# Altium schematic sheet Front_Panel.SchDoc
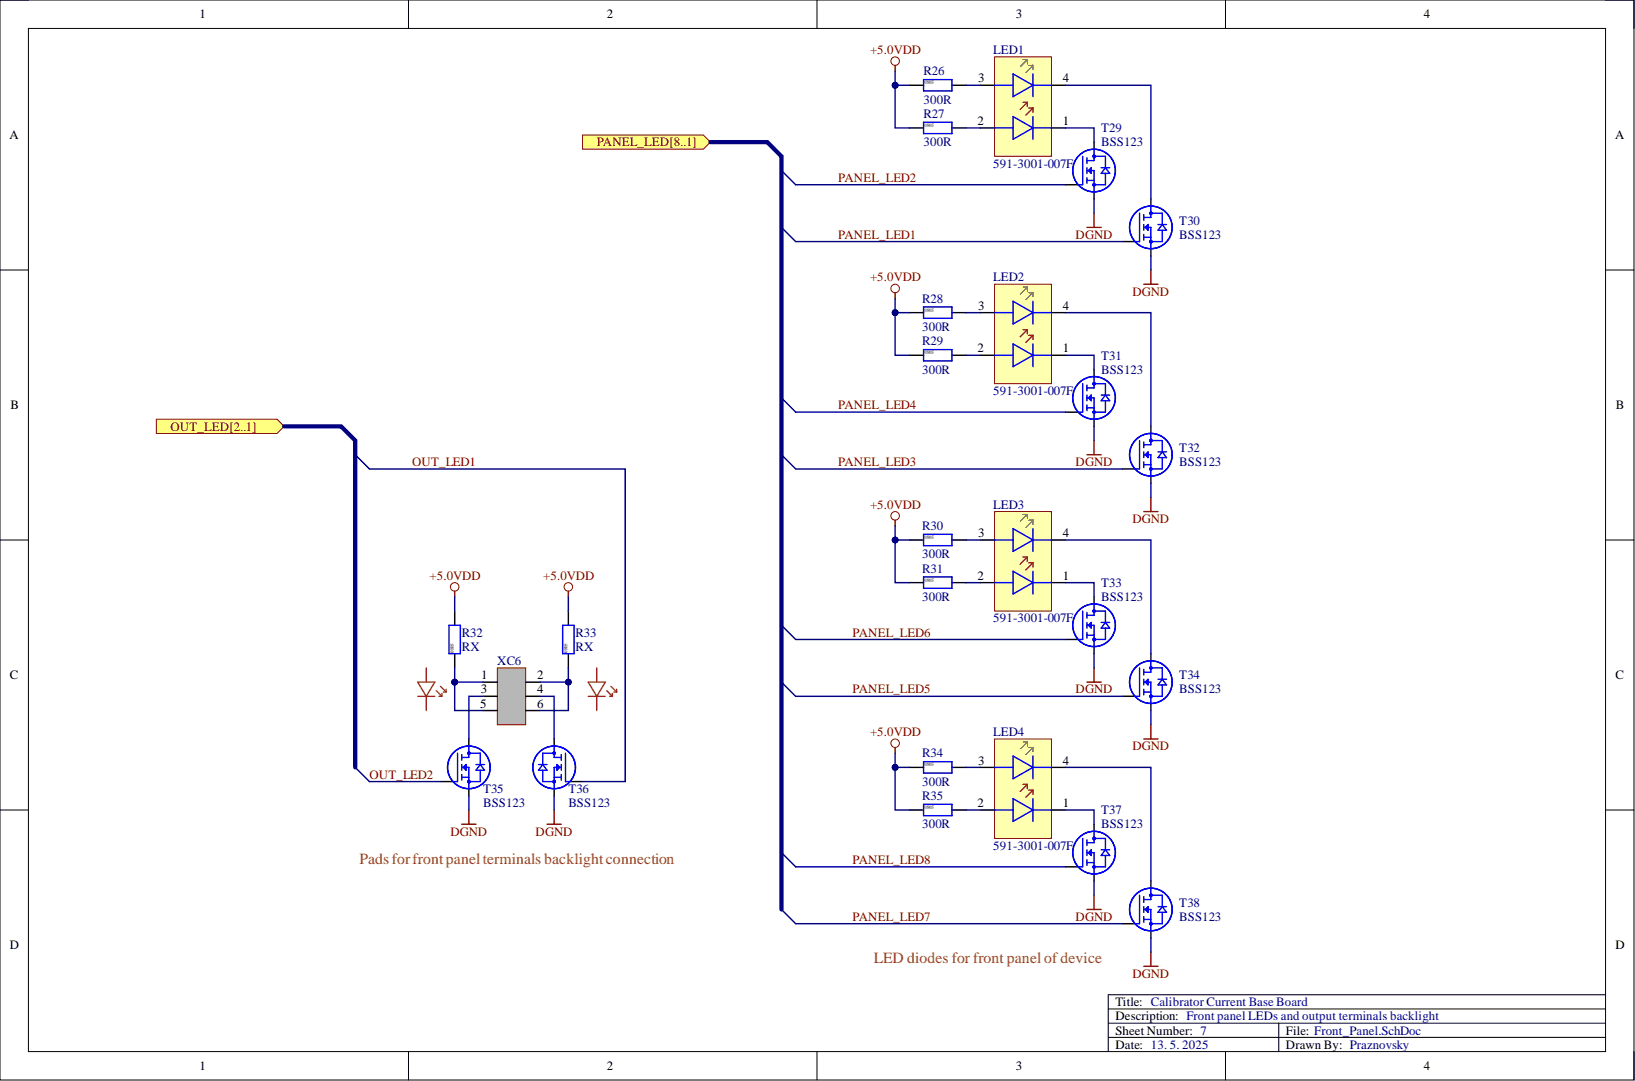
[diagram p0: Front_Panel.SchDoc]

## Parts
LED1  591-3001-007F  [591-3x0x-0xx]
LED2  591-3001-007F  [591-3x0x-0xx]
LED3  591-3001-007F  [591-3x0x-0xx]
LED4  591-3001-007F  [591-3x0x-0xx]
R26  300R  [R0805]
R27  300R  [R0805]
R28  300R  [R0805]
R29  300R  [R0805]
R30  300R  [R0805]
R31  300R  [R0805]
R32  RX  [R0805]
R33  RX  [R0805]
R34  300R  [R0805]
R35  300R  [R0805]
T29  BSS123  [SOT-23-3]
T30  BSS123  [SOT-23-3]
T31  BSS123  [SOT-23-3]
T32  BSS123  [SOT-23-3]
T33  BSS123  [SOT-23-3]
T34  BSS123  [SOT-23-3]
T35  BSS123  [SOT-23-3]
T36  BSS123  [SOT-23-3]
T37  BSS123  [SOT-23-3]
T38  BSS123  [SOT-23-3]
XC6  Pin Header 3x2 male  [Pin Header 3x2 male]  (value not drawn)

## Ports
OUT_LED1, OUT_LED2, OUT_LED[2..1], PANEL_LED1, PANEL_LED2, PANEL_LED3, PANEL_LED4, PANEL_LED5, PANEL_LED6, PANEL_LED7, PANEL_LED8, PANEL_LED[8..1]

## Nets
+5.0VDD: R26.1 R27.1 R28.1 R29.1 R30.1 R31.1 R32.2 R33.2 R34.1 R35.1
DGND: T29.2 T30.2 T31.2 T32.2 T33.2 T34.2 T35.2 T36.2 T37.2 T38.2
OUT_LED1: T36.1 ; port OUT_LED[2..1]  [also labelled ]
OUT_LED2: T35.1 ; port OUT_LED[2..1]  [also labelled ]
PANEL_LED1: T30.1 ; port PANEL_LED[8..1]  [also labelled ]
PANEL_LED2: T29.1 ; port PANEL_LED[8..1]  [also labelled ]
PANEL_LED3: T32.1 ; port PANEL_LED[8..1]  [also labelled ]
PANEL_LED4: T31.1 ; port PANEL_LED[8..1]  [also labelled ]
PANEL_LED5: T34.1 ; port PANEL_LED[8..1]  [also labelled ]
PANEL_LED6: T33.1 ; port PANEL_LED[8..1]  [also labelled ]
PANEL_LED7: T38.1 ; port PANEL_LED[8..1]  [also labelled ]
PANEL_LED8: T37.1 ; port PANEL_LED[8..1]  [also labelled ]
NetLED1_1: LED1.1 T29.3
NetLED1_2: LED1.2 R27.2
NetLED1_3: LED1.3 R26.2
NetLED1_4: LED1.4 T30.3
NetLED2_1: LED2.1 T31.3
NetLED2_2: LED2.2 R29.2
NetLED2_3: LED2.3 R28.2
NetLED2_4: LED2.4 T32.3
NetLED3_1: LED3.1 T33.3
NetLED3_2: LED3.2 R31.2
NetLED3_3: LED3.3 R30.2
NetLED3_4: LED3.4 T34.3
NetLED4_1: LED4.1 T37.3
NetLED4_2: LED4.2 R35.2
NetLED4_3: LED4.3 R34.2
NetLED4_4: LED4.4 T38.3
NetR32_1: R32.1 XC6.1 XC6.5
NetR33_1: R33.1 XC6.2 XC6.6
NetT35_3: T35.3 XC6.3
NetT36_3: T36.3 XC6.4

## Library data of the parts
LED1-LED4 = CMP-00092-1: Prism® CBI®Circuit Board Indicator Surface Mount LED, Round Lens; Component Kind: Standard; Library Name: Calibrator
R26-R35 = CMP-00063-2: Resistor 0805; Component Kind: Standard; Library Name: Calibrator
T29-T38 = CMP-00033-6: N-channel TrenchMOS transistor Logic level FET; Component Kind: Standard; Library Name: Calibrator
XC6 = CMP-00086-2: Component Kind: Standard; Description: Pin Header 3x2 male; Library Name: Calibrator
